# Altium schematic sheet F4_WING.SchDoc
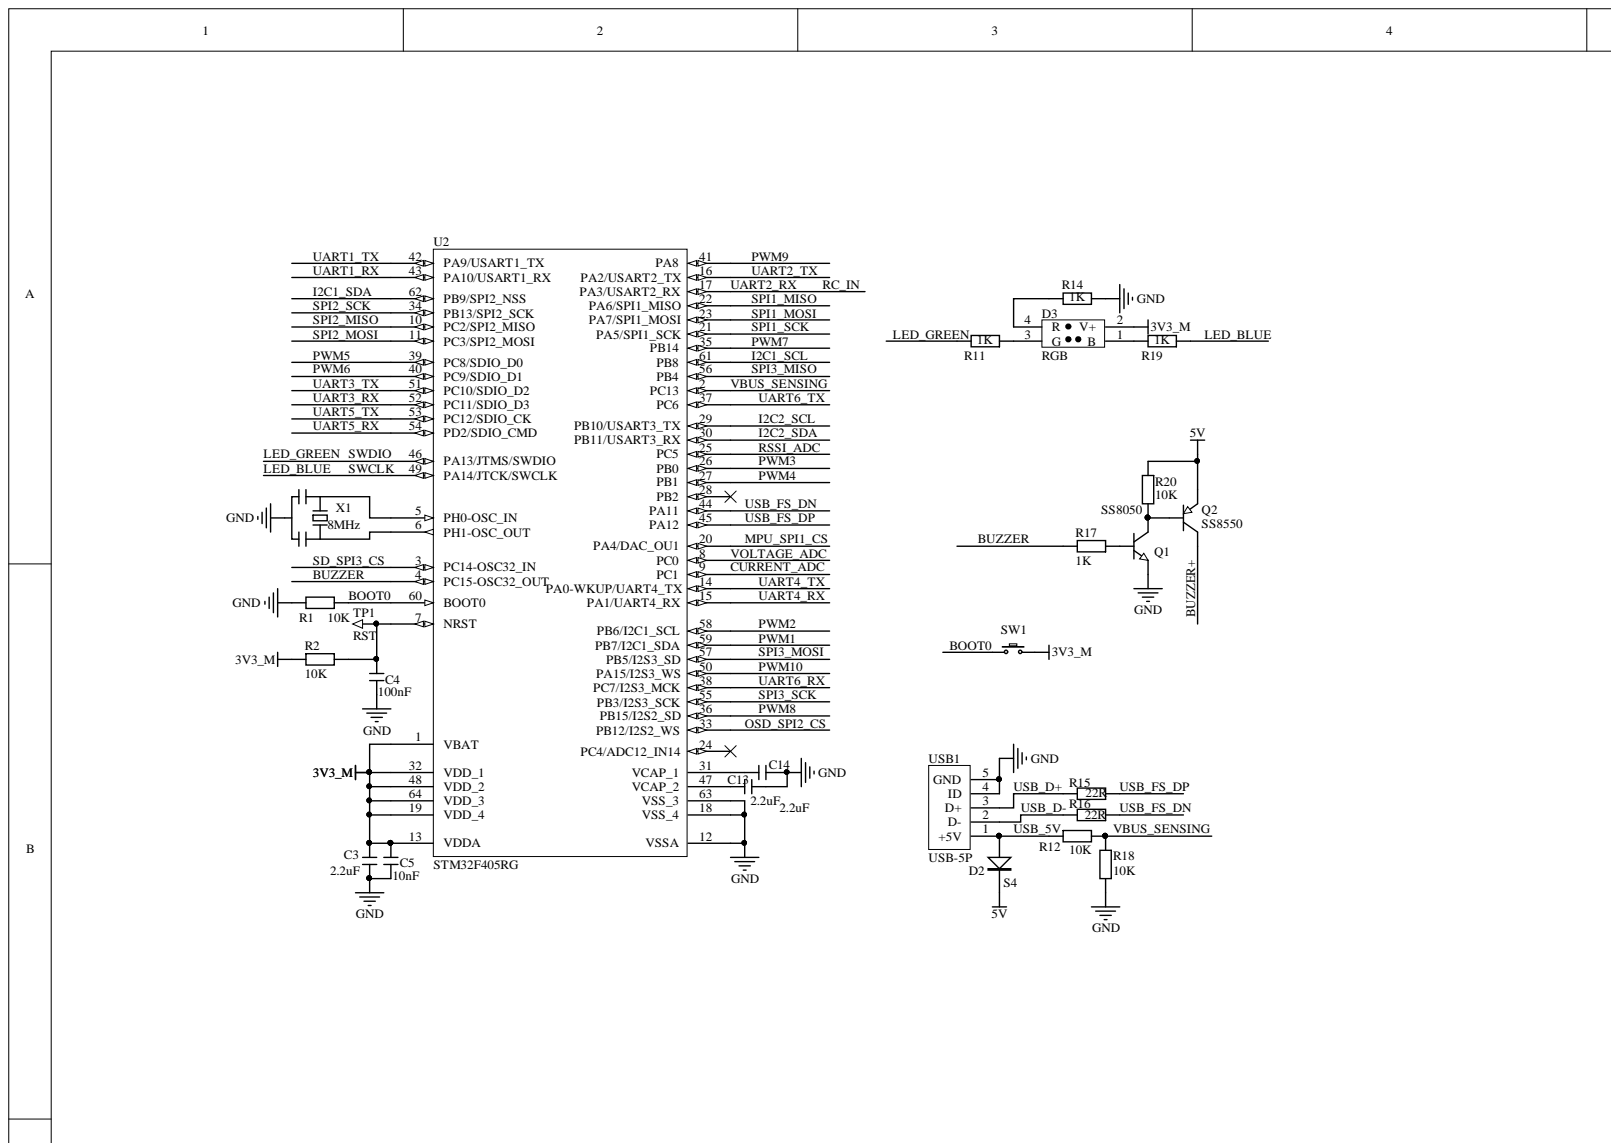
[diagram p0: F4_WING.SchDoc, top left]
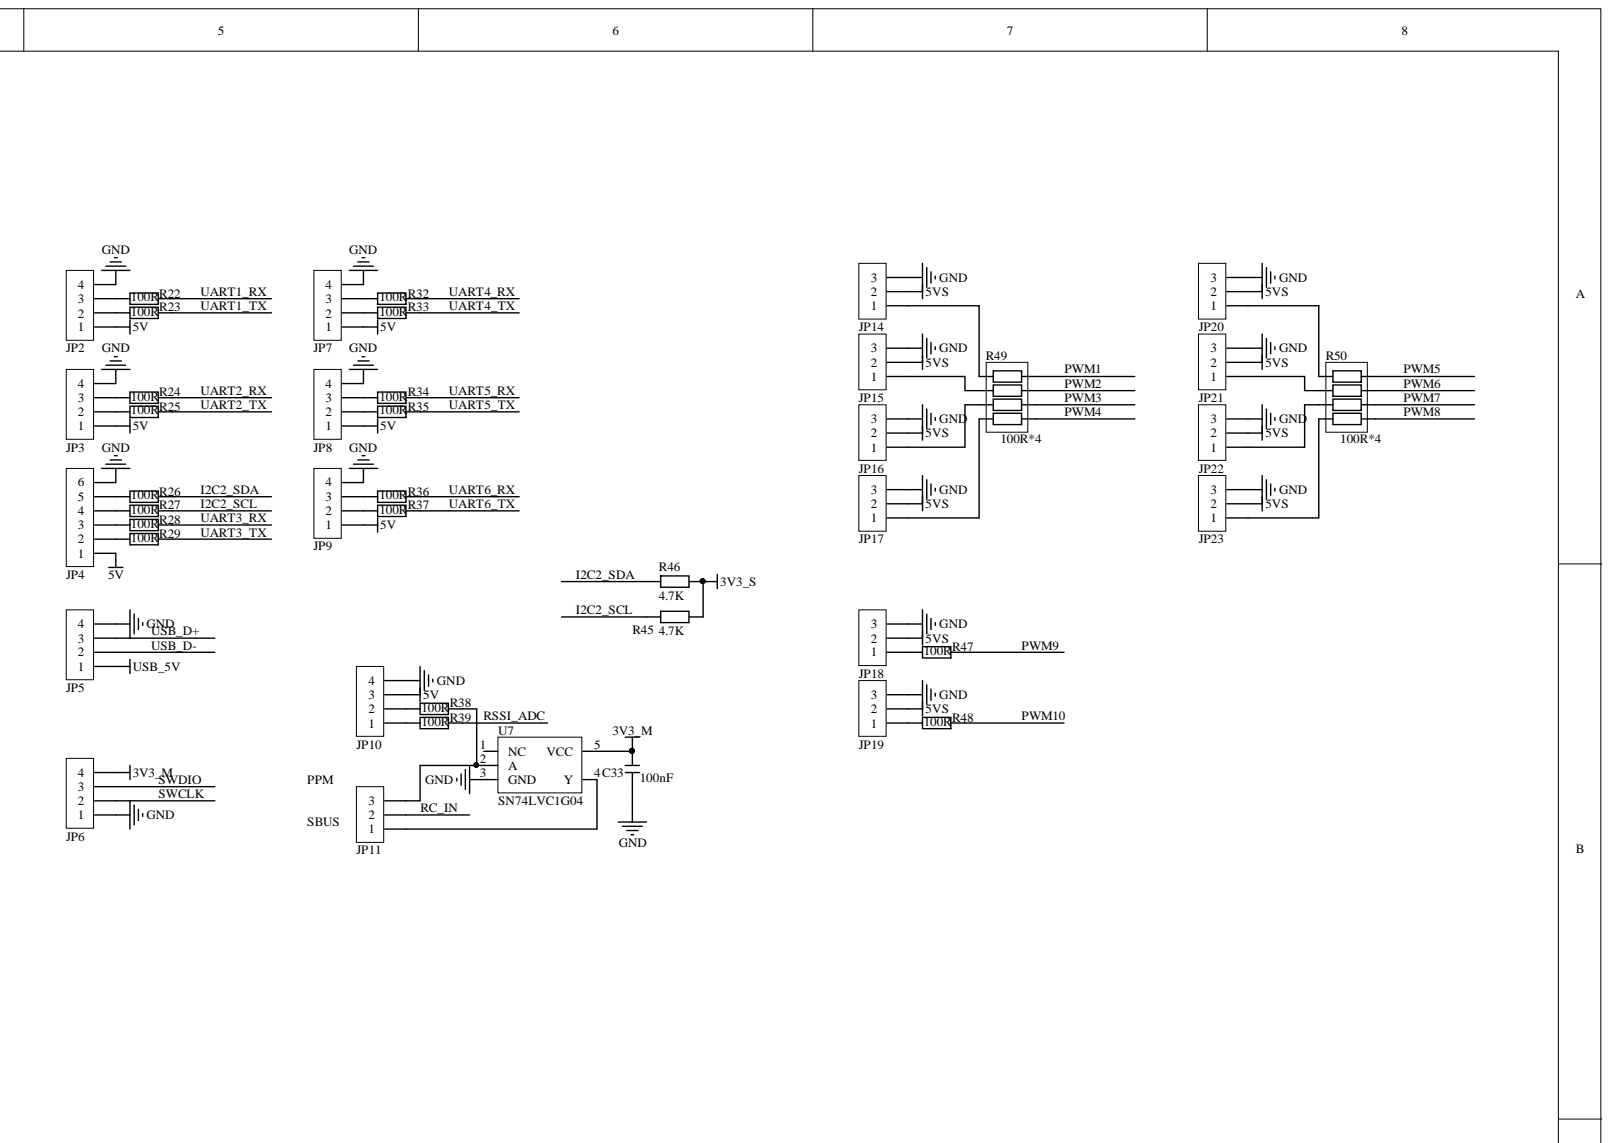
[diagram p1: F4_WING.SchDoc, top right]
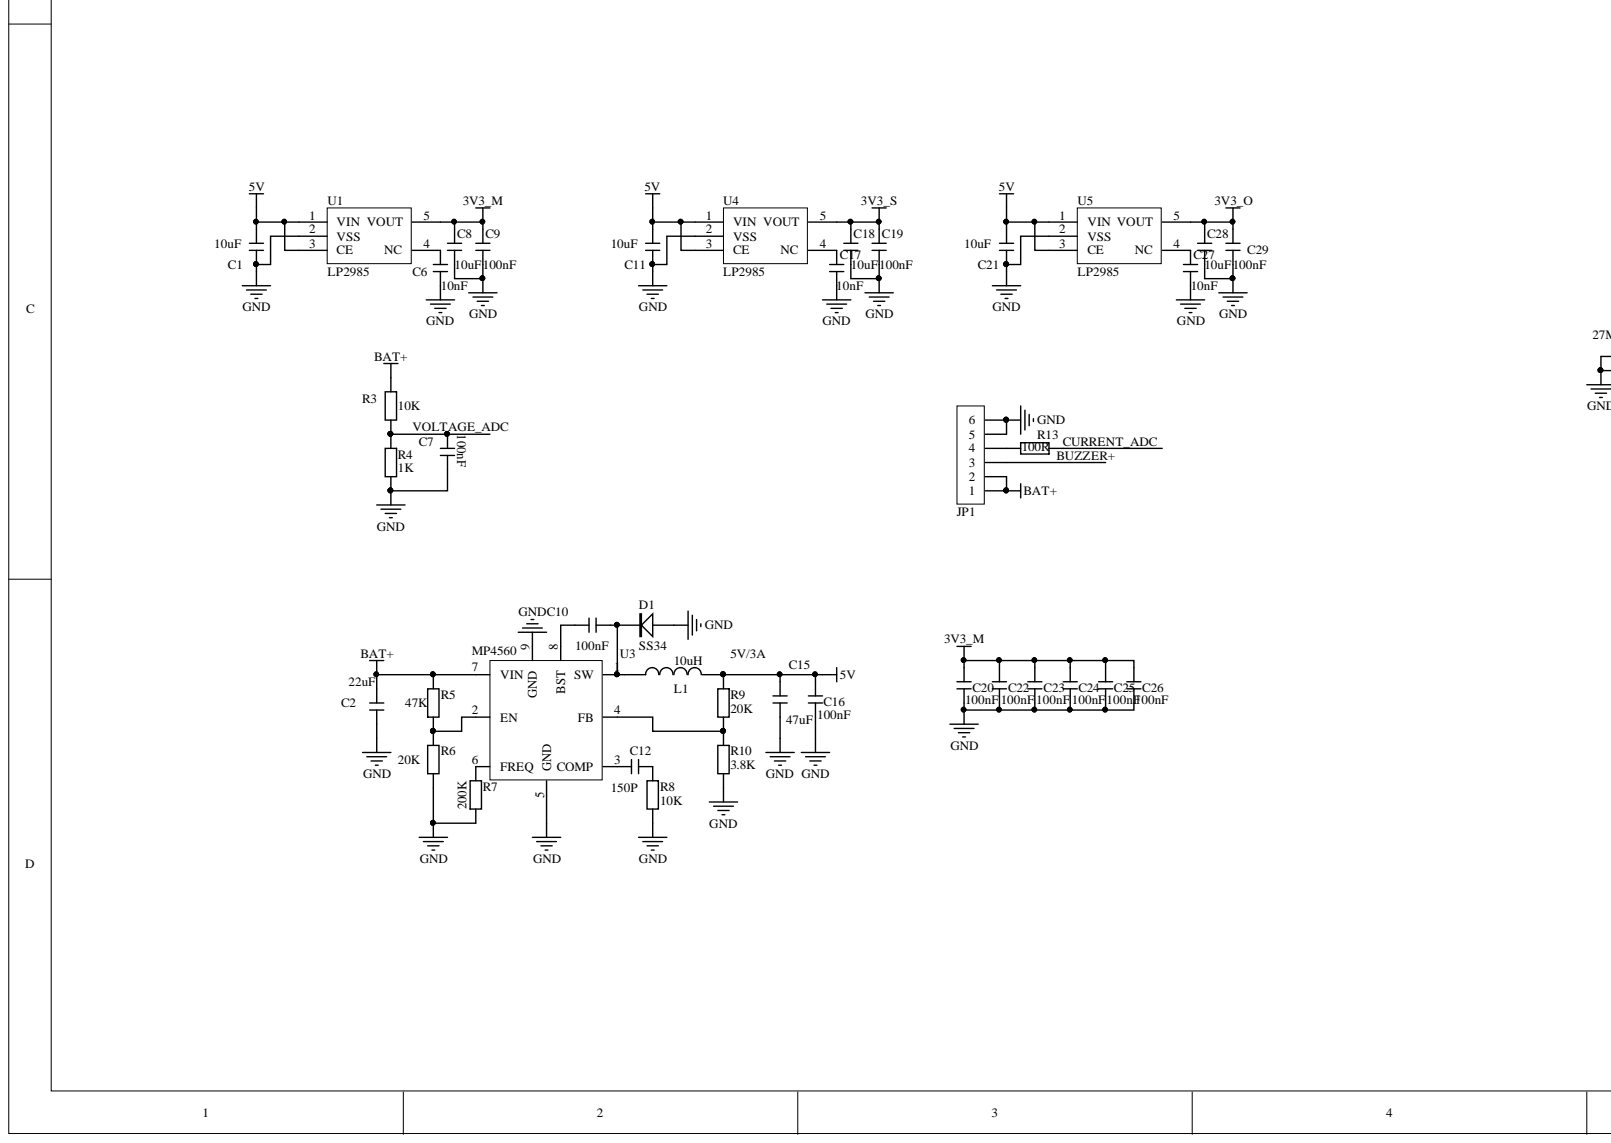
[diagram p2: F4_WING.SchDoc, bottom left]
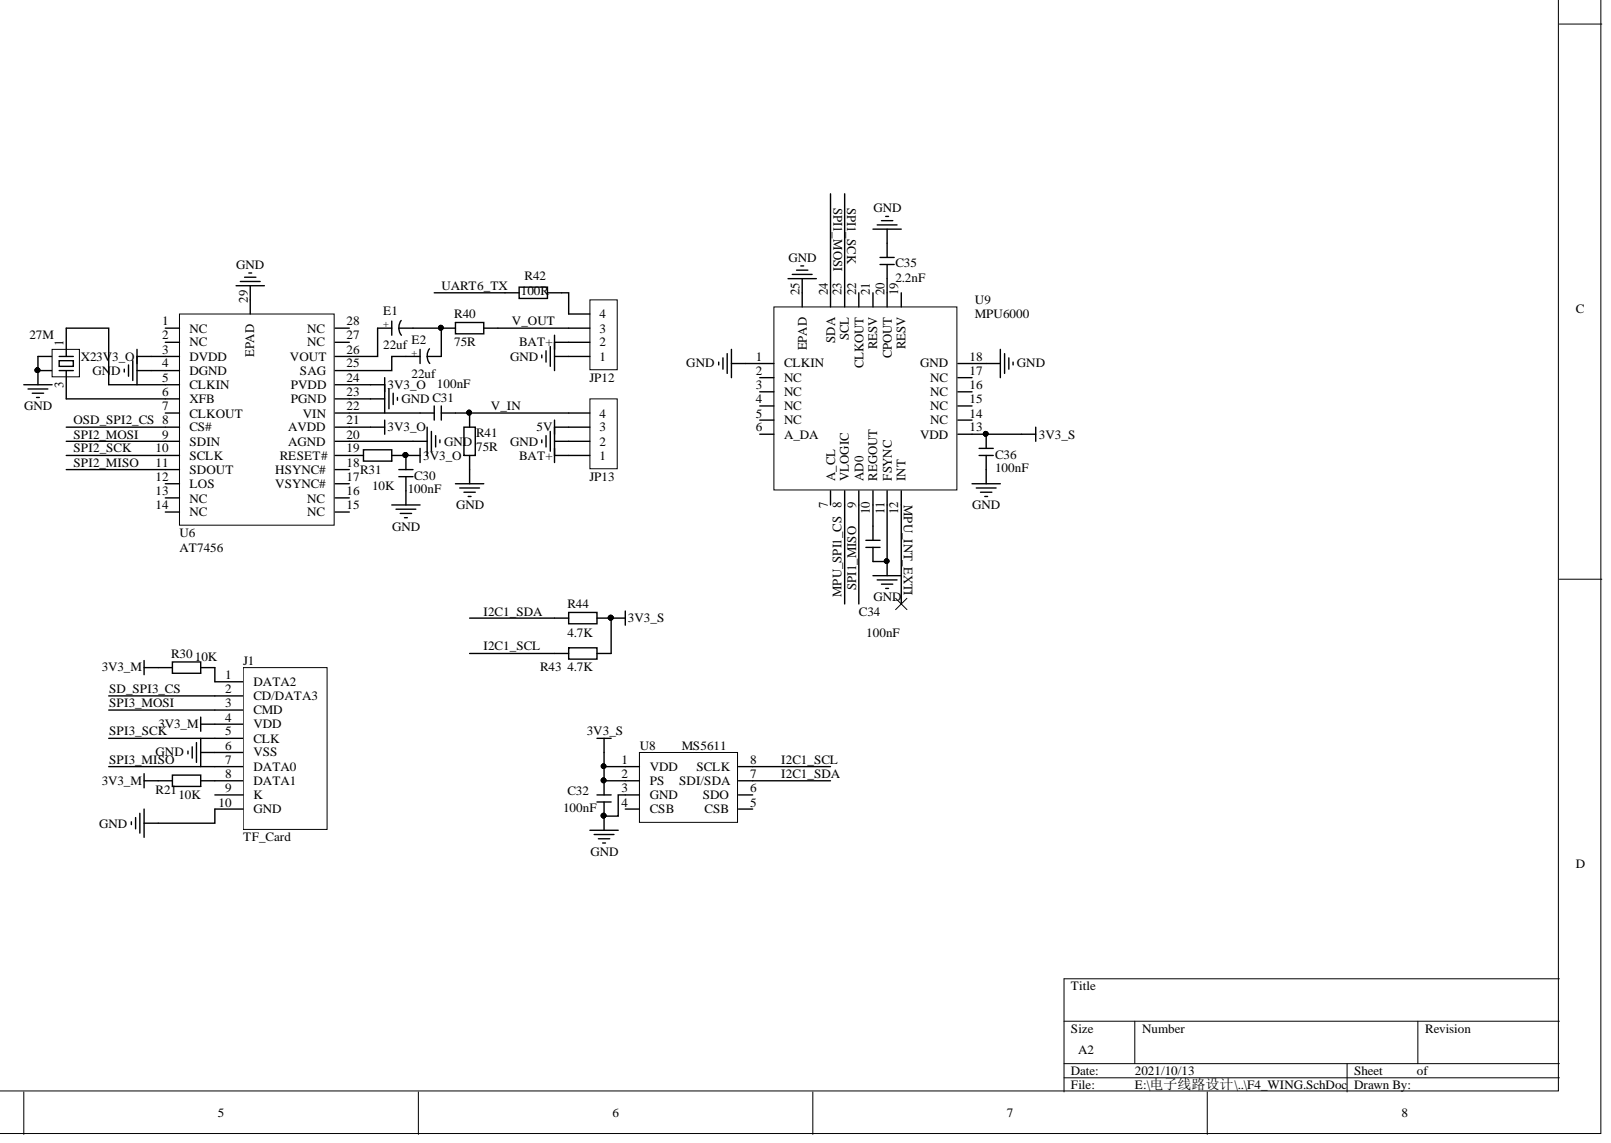
[diagram p3: F4_WING.SchDoc, bottom right]

## Parts
C1  10uF  [C 0603_L]
C2  22uF  [C 1210_L]
C3  2.2uF  [C 0402_L]
C4  100nF  [C 0402_L]
C5  10nF  [C 0402_L]
C6  10nF  [C 0402_L]
C7  100nF  [C 0402_L]
C8  10uF  [C 0603_L]
C9  100nF  [C 0402_L]
C10  100nF  [C 0402_L]
C11  10uF  [C 0603_L]
C12  150P  [C 0402_L]
C13  2.2uF  [C 0402_L]
C14  2.2uF  [C 0402_L]
C15  47uF  [C 1210_L]
C16  100nF  [C 0402_L]
C17  10nF  [C 0402_L]
C18  10uF  [C 0603_L]
C19  100nF  [C 0402_L]
C20  100nF  [C 0402_L]
C21  10uF  [C 0603_L]
C22  100nF  [C 0402_L]
C23  100nF  [C 0402_L]
C24  100nF  [C 0402_L]
C25  100nF  [C 0402_L]
C26  100nF  [C 0402_L]
C27  10nF  [C 0402_L]
C28  10uF  [C 0603_L]
C29  100nF  [C 0402_L]
C30  100nF  [C 0402_L]
C31  100nF  [C 0402_L]
C32  100nF  [C 0402_L]
C33  100nF  [C 0402_L]
C34  100nF  [C 0402_L]
C35  2.2nF  [C 0402_L]
C36  100nF  [C 0402_L]
D1  SS34  [SMA]
D2  S4  [SOD123]
D3  RGB  [LED-3528]
E1  22uf  [C3216]
E2  22uf  [C3216]
J1  TF_Card  [Micro SD]
JP1  HDR-1X6  [GH1.25-WS-6P]  (value not drawn)
JP2  HDR-1X4  [GH1.25-LS-4P]  (value not drawn)
JP3  HDR-1X4  [GH1.25-LS-4P]  (value not drawn)
JP4  HDR-1X6  [GH1.25-LS-6P]  (value not drawn)
JP5  HDR-1X4  [GH1.25-LS-4P]  (value not drawn)
JP6  HDR-1X4  [J-LINK]  (value not drawn)
JP7  HDR-1X4  [GH1.25-LS-4P]  (value not drawn)
JP8  HDR-1X4  [GH1.25-LS-4P]  (value not drawn)
JP9  HDR-1X4  [GH1.25-LS-4P]  (value not drawn)
JP10  HDR-1X4  [GH1.25-LS-4P]  (value not drawn)
JP11  HDR-1X3  [JUMPER_1.25_3P]  (value not drawn)
JP12  HDR-1X4  [GH1.25-LS-4P]  (value not drawn)
JP13  HDR-1X4  [GH1.25-LS-4P]  (value not drawn)
JP14  HDR-1X3  [HDR_2.54_3P]  (value not drawn)
JP15  HDR-1X3  [HDR_2.54_3P]  (value not drawn)
JP16  HDR-1X3  [HDR_2.54_3P]  (value not drawn)
JP17  HDR-1X3  [HDR_2.54_3P]  (value not drawn)
JP18  HDR-1X3  [HDR_2.54_3P]  (value not drawn)
JP19  HDR-1X3  [HDR_2.54_3P]  (value not drawn)
JP20  HDR-1X3  [HDR_2.54_3P]  (value not drawn)
JP21  HDR-1X3  [HDR_2.54_3P]  (value not drawn)
JP22  HDR-1X3  [HDR_2.54_3P]  (value not drawn)
JP23  HDR-1X3  [HDR_2.54_3P]  (value not drawn)
L1  10uH  [CD104]
Q1  SS8050  [SOT23-3L]
Q2  SS8550  [SOT23-3N]
R1  10K  [R 0402_L]
R2  10K  [R 0402_L]
R3  10K  [R 0402_L]
R4  1K  [R 0402_L]
R5  47K  [R 0402_L]
R6  20K  [R 0402_L]
R7  200K  [R 0402_L]
R8  10K  [R 0402_L]
R9  20K  [R 0402_L]
R10  3.8K  [R 0402_L]
R11  1K  [R 0402_L]
R12  10K  [R 0402_L]
R13  100R  [R 0402_L]
R14  1K  [R 0402_L]
R15  22R  [R 0402_L]  (value not drawn)
R16  22R  [R 0402_L]  (value not drawn)
R17  1K  [R 0402_L]
R18  10K  [R 0402_L]
R19  1K  [R 0402_L]
R20  10K  [R 0402_L]
R21  10K  [R 0402_L]
R22  100R  [R 0402_L]
R23  100R  [R 0402_L]
R24  100R  [R 0402_L]
R25  100R  [R 0402_L]
R26  100R  [R 0402_L]
R27  100R  [R 0402_L]
R28  100R  [R 0402_L]
R29  100R  [R 0402_L]
R30  10K  [R 0402_L]
R31  10K  [R 0402_L]
R32  100R  [R 0402_L]
R33  100R  [R 0402_L]
R34  100R  [R 0402_L]
R35  100R  [R 0402_L]
R36  100R  [R 0402_L]
R37  100R  [R 0402_L]
R38  100R  [R 0402_L]
R39  100R  [R 0402_L]
R40  75R  [R 0402_L]
R41  75R  [R 0402_L]
R42  100R  [R 0402_L]
R43  4.7K  [R 0402_L]
R44  4.7K  [R 0402_L]
R45  4.7K  [R 0402_L]
R46  4.7K  [R 0402_L]
R47  100R  [R 0402_L]
R48  100R  [R 0402_L]
R49  100R*4  [RCA-8P4R-0402]
R50  100R*4  [RCA-8P4R-0402]
SW1  BOOT0  [TSW 3*6*4.3-S-W]
TP1  RST  [TEST 1.2]
U1  LP2985  [SOT23-5L]
U2  STM32F405RG  [LQFP64 10X10_N]
U3  MP4560  [ESOP8_L]
U4  LP2985  [SOT23-5L]
U5  LP2985  [SOT23-5L]
U6  AT7456  [TSSOP28_PL]
U7  SN74LVC1G04  [SOT23-5L]
U8  MS5611  [MS5611]
U9  MPU6000  [QFN24 4x4_L]
USB1  USB-5P  [USB-micro_C]
X1  8MHz  [OSC-3213]
X2  27M  [OSC 3225-4P]

## Nets
3V3_M: C3.2 C5.2 C8.2 C9.2 C20.2 C22.2 C23.2 C24.2 C25.2 C26.2 C33.2 D3.2(V+) J1.4(VDD) JP6.4 R2.2 R21.1 R30.1 SW1.2 U1.5(VOUT) U2.1(VBAT) U2.13(VDDA) U2.19(VDD_4) U2.32(VDD_1) U2.48(VDD_2) U2.64(VDD_3) U7.5(VCC)
3V3_O: C28.2 C29.2 C30.2 R31.2 U5.5(VOUT) U6.3(DVDD) U6.21(AVDD) U6.24(PVDD)
3V3_S: C18.2 C19.2 C32.1 C36.1 R43.1 R44.1 R45.1 R46.1 U4.5(VOUT) U8.1(VDD) U8.2(PS) U9.13(VDD)
5V: C1.2 C11.2 C15.2 C16.2 C21.2 D2.2 JP2.1 JP3.1 JP4.1 JP7.1 JP8.1 JP9.1 JP10.3 JP13.3 L1.2 Q2.2 R9.2 R20.2 U1.1(VIN) U1.3(CE) U4.1(VIN) U4.3(CE) U5.1(VIN) U5.3(CE)
5VS: JP14.2 JP15.2 JP16.2 JP17.2 JP18.2 JP19.2 JP20.2 JP21.2 JP22.2 JP23.2
BAT+: C2.2 JP1.1 JP1.2 JP12.2 JP13.1 R3.2 R5.1 U3.7(VIN)
GND: C1.1 C2.1 C3.1 C4.1 C5.1 C6.1 C7.1 C8.1 C9.1 C11.1 C13.2 C14.2 C15.1 C16.1 C17.1 C18.1 C19.1 C20.1 C21.1 C22.1 C23.1 C24.1 C25.1 C26.1 C27.1 C28.1 C29.1 C30.1 C32.2 C33.1 C34.1 C35.1 C36.2 D1.1 J1.6(VSS) J1.10(GND) JP1.5 JP1.6 JP2.4 JP3.4 JP4.6 JP5.4 JP6.1 JP7.4 JP8.4 JP9.4 JP10.4 JP12.1 JP13.2 JP14.3 JP15.3 JP16.3 JP17.3 JP18.3 JP19.3 JP20.3 JP21.3 JP22.3 JP23.3 Q1.2 R1.1 R4.1 R6.2 R7.2 R8.1 R10.1 R14.2 R18.1 R41.2 U1.2(VSS) U2.12(VSSA) U2.18(VSS_4) U2.63(VSS_3) U3.5(GND) U3.9(GND) U4.2(VSS) U5.2(VSS) U6.4(DGND) U6.20(AGND) U6.23(PGND) U6.29(EPAD) U7.3(GND) U8.3(GND) U9.1(CLKIN) U9.11(FSYNC) U9.18(GND) U9.25(EPAD) USB1.4(ID) USB1.5(GND) X1.2 X2.2 X2.4
BOOT0: R1.2 SW1.1 U2.60(BOOT0)
BUZZER: R17.1 U2.4(PC15-OSC32_OUT)
BUZZER+: JP1.3 Q2.3
CURRENT_ADC: R13.2 U2.9(PC1)
I2C1_SCL: R43.2 U2.61(PB8) U8.8(SCLK)
I2C1_SDA: R44.2 U2.62(PB9/SPI2_NSS) U8.7(SDI/SDA)
I2C2_SCL: R27.2 R45.2 U2.29(PB10/USART3_TX)
I2C2_SDA: R26.2 R46.2 U2.30(PB11/USART3_RX)
LED_BLUE: JP6.2 R19.2 U2.49(PA14/JTCK/SWCLK)
LED_GREEN: JP6.3 R11.1 U2.46(PA13/JTMS/SWDIO)
MPU_INT_EXTI: U9.12(INT)
MPU_SPI1_CS: U2.20(PA4/DAC_OU1) U9.8(VLOGIC)
OSD_SPI2_CS: U2.33(PB12/I2S2_WS) U6.8(CS#)
PWM1: R49.8 U2.59(PB7/I2C1_SDA)
PWM2: R49.7 U2.58(PB6/I2C1_SCL)
PWM3: R49.6 U2.26(PB0)
PWM4: R49.5 U2.27(PB1)
PWM5: R50.8 U2.39(PC8/SDIO_D0)
PWM6: R50.7 U2.40(PC9/SDIO_D1)
PWM7: R50.6 U2.35(PB14)
PWM8: R50.5 U2.36(PB15/I2S2_SD)
PWM9: R47.2 U2.41(PA8)
PWM10: R48.2 U2.50(PA15/I2S3_WS)
RC_IN: JP11.2 R24.2 U2.17(PA3/USART2_RX)
RSSI_ADC: R39.2 U2.25(PC5)
SD_SPI3_CS: J1.2(CD/DATA3) U2.3(PC14-OSC32_IN)
SPI1_MISO: U2.22(PA6/SPI1_MISO) U9.9(AD0)
SPI1_MOSI: U2.23(PA7/SPI1_MOSI) U9.24(SDA)
SPI1_SCK: U2.21(PA5/SPI1_SCK) U9.23(SCL)
SPI2_MISO: U2.10(PC2/SPI2_MISO) U6.11(SDOUT)
SPI2_MOSI: U2.11(PC3/SPI2_MOSI) U6.9(SDIN)
SPI2_SCK: U2.34(PB13/SPI2_SCK) U6.10(SCLK)
SPI3_MISO: J1.7(DATA0) U2.56(PB4)
SPI3_MOSI: J1.3(CMD) U2.57(PB5/I2S3_SD)
SPI3_SCK: J1.5(CLK) U2.55(PB3/I2S3_SCK)
UART1_RX: R22.2 U2.43(PA10/USART1_RX)
UART1_TX: R23.2 U2.42(PA9/USART1_TX)
UART2_TX: R25.2 U2.16(PA2/USART2_TX)
UART3_RX: R28.2 U2.52(PC11/SDIO_D3)
UART3_TX: R29.2 U2.51(PC10/SDIO_D2)
UART4_RX: R32.2 U2.15(PA1/UART4_RX)
UART4_TX: R33.2 U2.14(PA0-WKUP/UART4_TX)
UART5_RX: R34.2 U2.54(PD2/SDIO_CMD)
UART5_TX: R35.2 U2.53(PC12/SDIO_CK)
UART6_RX: R36.2 U2.38(PC7/I2S3_MCK)
UART6_TX: R37.2 R42.1 U2.37(PC6)
USB_5V: D2.1 JP5.1 R12.2 USB1.1(+5V)
USB_D+: JP5.3 R15.1 USB1.3(D+)
USB_D-: JP5.2 R16.1 USB1.2(D-)
USB_FS_DN: R16.2 U2.44(PA11)
USB_FS_DP: R15.2 U2.45(PA12)
V_IN: C31.1 JP13.4 R41.1
V_OUT: JP12.3 R40.1
VBUS_SENSING: R12.1 R18.2 U2.2(PC13)
VOLTAGE_ADC: C7.2 R3.1 R4.2 U2.8(PC0)
NetC4_2: C4.2 R2.1 TP1.1 U2.7(NRST)
NetC6_2: C6.2 U1.4(NC)
NetC10_1: C10.1 D1.2 L1.1 U3.1(SW)
NetC10_2: C10.2 U3.8(BST)
NetC12_1: C12.1 U3.3(COMP)
NetC12_2: C12.2 R8.2
NetC13_1: C13.1 U2.47(VCAP_2)
NetC14_1: C14.1 U2.31(VCAP_1)
NetC17_2: C17.2 U4.4(NC)
NetC27_2: C27.2 U5.4(NC)
NetC31_2: C31.2 U6.22(VIN)
NetC34_2: C34.2 U9.10(REGOUT)
NetC35_2: C35.2 U9.20(CPOUT)
NetD3_1: D3.1(B) R19.1
NetD3_3: D3.3(G) R11.2
NetD3_4: D3.4(R) R14.1
NetE1_1: E1.1 U6.26(VOUT)
NetE1_2: E1.2 E2.2 R40.2
NetE2_1: E2.1 U6.25(SAG)
NetJ1_1: J1.1(DATA2) R30.2
NetJ1_8: J1.8(DATA1) R21.2
NetJP1_4: JP1.4 R13.1
NetJP2_2: JP2.2 R23.1
NetJP2_3: JP2.3 R22.1
NetJP3_2: JP3.2 R25.1
NetJP3_3: JP3.3 R24.1
NetJP4_2: JP4.2 R29.1
NetJP4_3: JP4.3 R28.1
NetJP4_4: JP4.4 R27.1
NetJP4_5: JP4.5 R26.1
NetJP7_2: JP7.2 R33.1
NetJP7_3: JP7.3 R32.1
NetJP8_2: JP8.2 R35.1
NetJP8_3: JP8.3 R34.1
NetJP9_2: JP9.2 R37.1
NetJP9_3: JP9.3 R36.1
NetJP10_1: JP10.1 R39.1
NetJP10_2: JP10.2 R38.1
NetJP11_1: JP11.1 U7.4(Y)
NetJP11_3: JP11.3 R38.2 U7.2(A)
NetJP12_4: JP12.4 R42.2
NetJP14_1: JP14.1 R49.1
NetJP15_1: JP15.1 R49.2
NetJP16_1: JP16.1 R49.3
NetJP17_1: JP17.1 R49.4
NetJP18_1: JP18.1 R47.1
NetJP19_1: JP19.1 R48.1
NetJP20_1: JP20.1 R50.1
NetJP21_1: JP21.1 R50.2
NetJP22_1: JP22.1 R50.3
NetJP23_1: JP23.1 R50.4
NetQ1_1: Q1.1 R17.2
NetQ1_3: Q1.3 Q2.1 R20.1
NetR5_2: R5.2 R6.1 U3.2(EN)
NetR7_1: R7.1 U3.6(FREQ)
NetR9_1: R9.1 R10.2 U3.4(FB)
NetR31_1: R31.1 U6.19(RESET#)
NetU2_5: U2.5(PH0-OSC_IN) X1.3
NetU2_6: U2.6(PH1-OSC_OUT) X1.1
NetU6_5: U6.5(CLKIN) X2.1
NetU6_6: U6.6(XFB) X2.3

Not connected: J1 9(K); U2 24(PC4/ADC12_IN14) 28(PB2); U6 1(NC) 2(NC) 7(CLKOUT) 12(LOS) 13(NC) 14(NC) 15(NC) 16(NC) 17(VSYNC#) 18(HSYNC#) 27(NC) 28(NC); U7 1(NC); U8 4(CSB) 5(CSB) 6(SDO); U9 2(NC) 3(NC) 4(NC) 5(NC) 6(A_DA) 7(A_CL) 14(NC) 15(NC) 16(NC) 17(NC) 19(RESV) 21(RESV) 22(CLKOUT)

## Library data of the parts
C1-C36 = C: 无极性贴片电容; 版权归属: 源创客; BOM名称: 无极性贴片电容; Component Kind: Standard; Library Name: 3.1   - 电阻-电容-电感.SchLib; 学习资源: www.ooocke.com
D1 D2 = SS14: 肖特基二极管; 版权归属: 源创客; 属性: 40V/1A; BOM名称: 肖特基二极管; Component Kind: Standard; Library Name: 8.1   - 二极管-整流桥.SchLib; 学习资源: www.ooocke.com
D3 = RGB_3528: Component Kind: Standard; Library Name: Schlib.SchLib
E1 E2 = C-CD: 贴片钽电容; 版权归属: 源创客; BOM名称: 贴片钽电容; Component Kind: Standard; Library Name: 3.1   - 电阻-电容-电感.SchLib; 学习资源: www.ooocke.com
J1 = TF_Card: TF卡座; 版权归属: 源创客; BOM名称: TF卡座; Component Kind: Standard; Library Name: 4.1   - 接口类.SchLib; 学习资源: www.ooocke.com
JP1 JP4 = Header 6: 6P接插件; 版权归属: 源创客; BOM名称: 6P接插件; Component Kind: Standard; Library Name: 4.5   - 接插件-脚距2.54.SchLib; 学习资源: www.ooocke.com
JP2 JP3 JP5-JP10 JP12 JP13 = Header 4: 4P接插件; 版权归属: 源创客; BOM名称: 4P接插件; Component Kind: Standard; Library Name: 4.5   - 接插件-脚距2.54.SchLib; 学习资源: www.ooocke.com
JP11 JP14-JP23 = Header 3: 3P接插件; 版权归属: 源创客; BOM名称: 3P接插件; Component Kind: Standard; Library Name: 4.5   - 接插件-脚距2.54.SchLib; 学习资源: www.ooocke.com
L1 = L: 小功率贴片电感; 版权归属: 源创客; BOM名称: 小功率贴片电感; Component Kind: Standard; Library Name: 3.1   - 电阻-电容-电感.SchLib; 学习资源: www.ooocke.com
Q1 = 8050-SMD: 高频放大-NPN型; 版权归属: 源创客; 属性: 40V 1.5A 1W 100MHZ; BOM名称: 高频放大-NPN型; Component Kind: Standard; Library Name: 6.2   - 三极管.SchLib; 学习资源: www.ooocke.com
Q2 = 8550-SMD: 高频放大-PNP型; 版权归属: 源创客; 属性: 40V 1.5A 1W 100MHZ; BOM名称: 高频放大-PNP型; Component Kind: Standard; Library Name: 6.2   - 三极管.SchLib; 学习资源: www.ooocke.com
R1-R48 = R: 贴片电阻; 版权归属: 源创客; BOM名称: 贴片电阻; Component Kind: Standard; Library Name: 3.1   - 电阻-电容-电感.SchLib; 学习资源: www.ooocke.com
R49 R50 = R-8P4R: 贴片网络排阻; 版权归属: 源创客; BOM名称: 贴片网络排阻; Component Kind: Standard; Library Name: 3.1   - 电阻-电容-电感.SchLib; 学习资源: www.ooocke.com
SW1 = TSW DIP-6x6_V: 侧按直插6x6轻触开关; 版权归属: 源创客; BOM名称: 直插6x6轻触开关; Component Kind: Standard; Library Name: 5.1   - 按键-开关.SchLib; 学习资源: www.ooocke.com
TP1 = TEST: 测试点; 版权归属: 源创客; BOM名称: 测试点; Component Kind: Standard; Library Name: 15.1 - 未分类器件.SchLib; 学习资源: www.ooocke.com
U1 U4 U5 = ME6211: Component Kind: Standard; Library Name: Schlib.SchLib
U2 = STM32F205RG: 32位STM单片机; 版权归属: 源创客; BOM名称: 32位STM单片机; Component Kind: Standard; Library Name: 2.1   - STM32系列单片机.SchLib; 学习资源: www.ooocke.com
USB1 = USB-5P: 微型USB母座; 版权归属: 源创客; BOM名称: 微型USB母座; Component Kind: Standard; Library Name: 4.1   - 接口类.SchLib; 学习资源: www.ooocke.com
X1 = XTAL-3P: 3脚无源脚晶振; BOM名称: 2脚无源脚晶振; 版权归属: 源创客; Component Kind: Standard; Library Name: Schlib.SchLib; 学习资源: www.ooocke.com
X2 = XTAL-4P: 4脚无源晶振; 版权归属: 源创客; BOM名称: 4脚无源晶振; Component Kind: Standard; Library Name: 10.1 - 保险丝-晶振.SchLib; 学习资源: www.ooocke.com
U3 = MP4560: Component Kind: Standard; Library Name: Schlib.SchLib
U6 = AT7456: Component Kind: Standard; Library Name: Schlib.SchLib
U7 = SN74LVC1G04: Component Kind: Standard; Library Name: Schlib.SchLib
U8 = MS5611: Component Kind: Standard; Library Name: Schlib.SchLib
U9 = MPU6000: Component Kind: Standard; Library Name: Schlib.SchLib
